# Altium schematic sheet Peripherals Schematic.SchDoc("Peripherals Schematic")
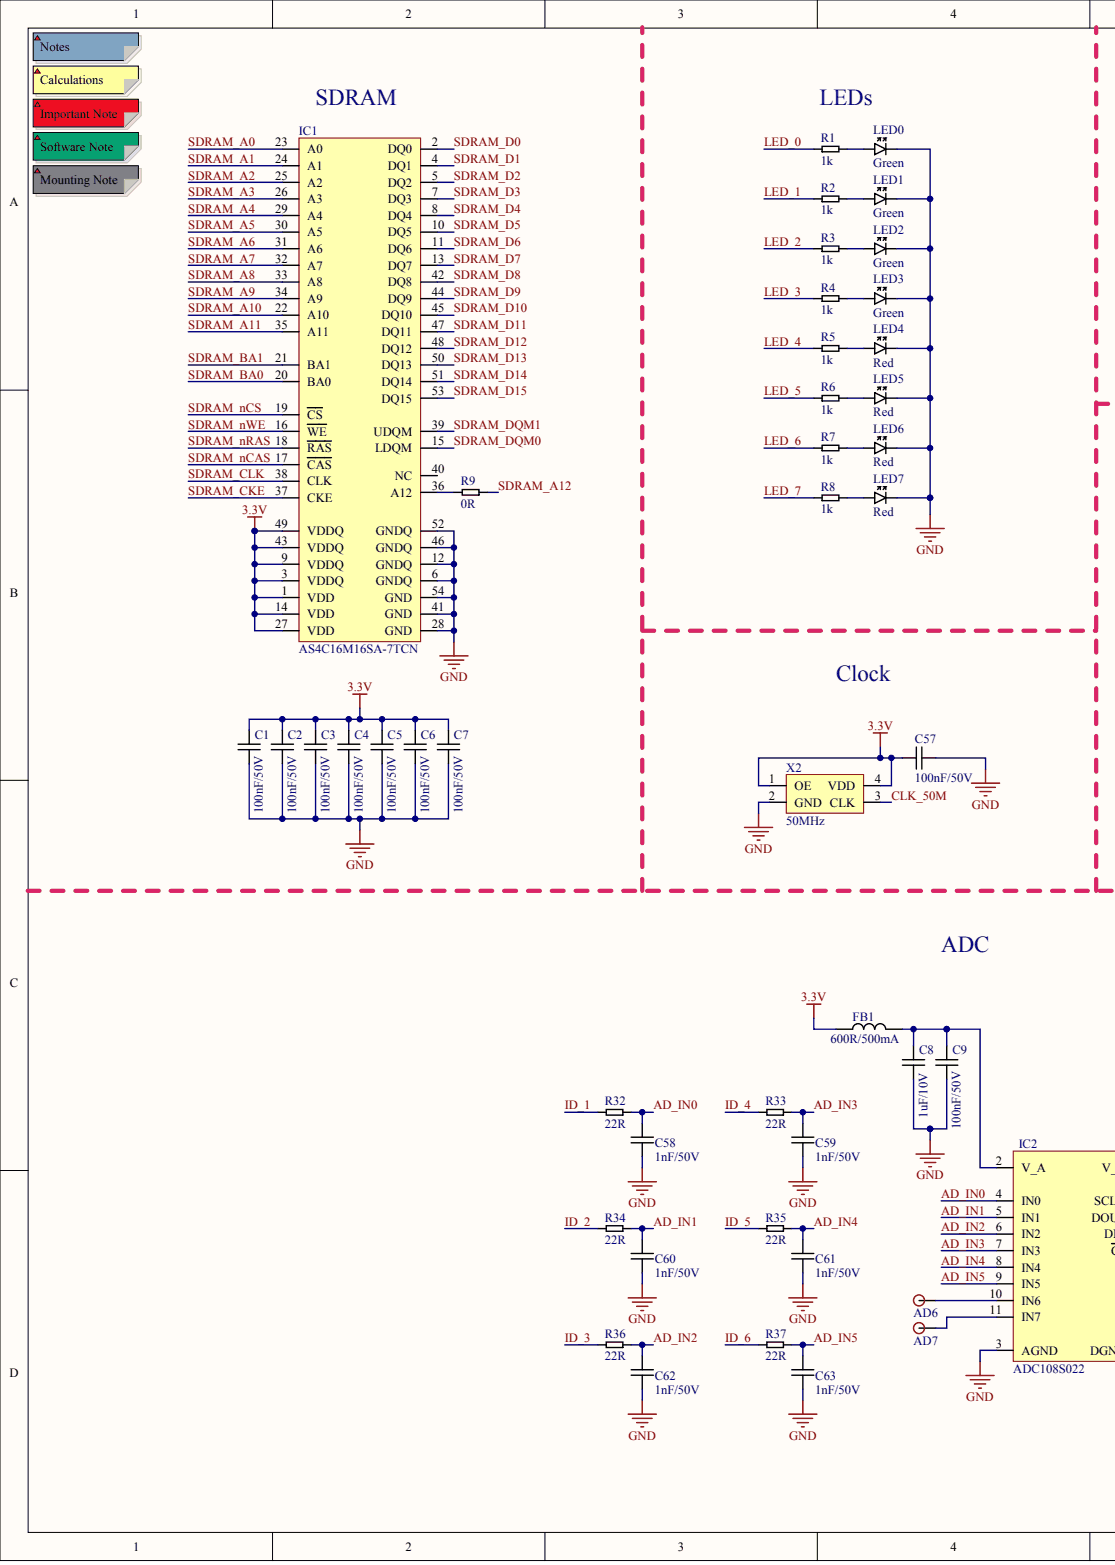
[diagram p0: Peripherals Schematic.SchDoc, left]
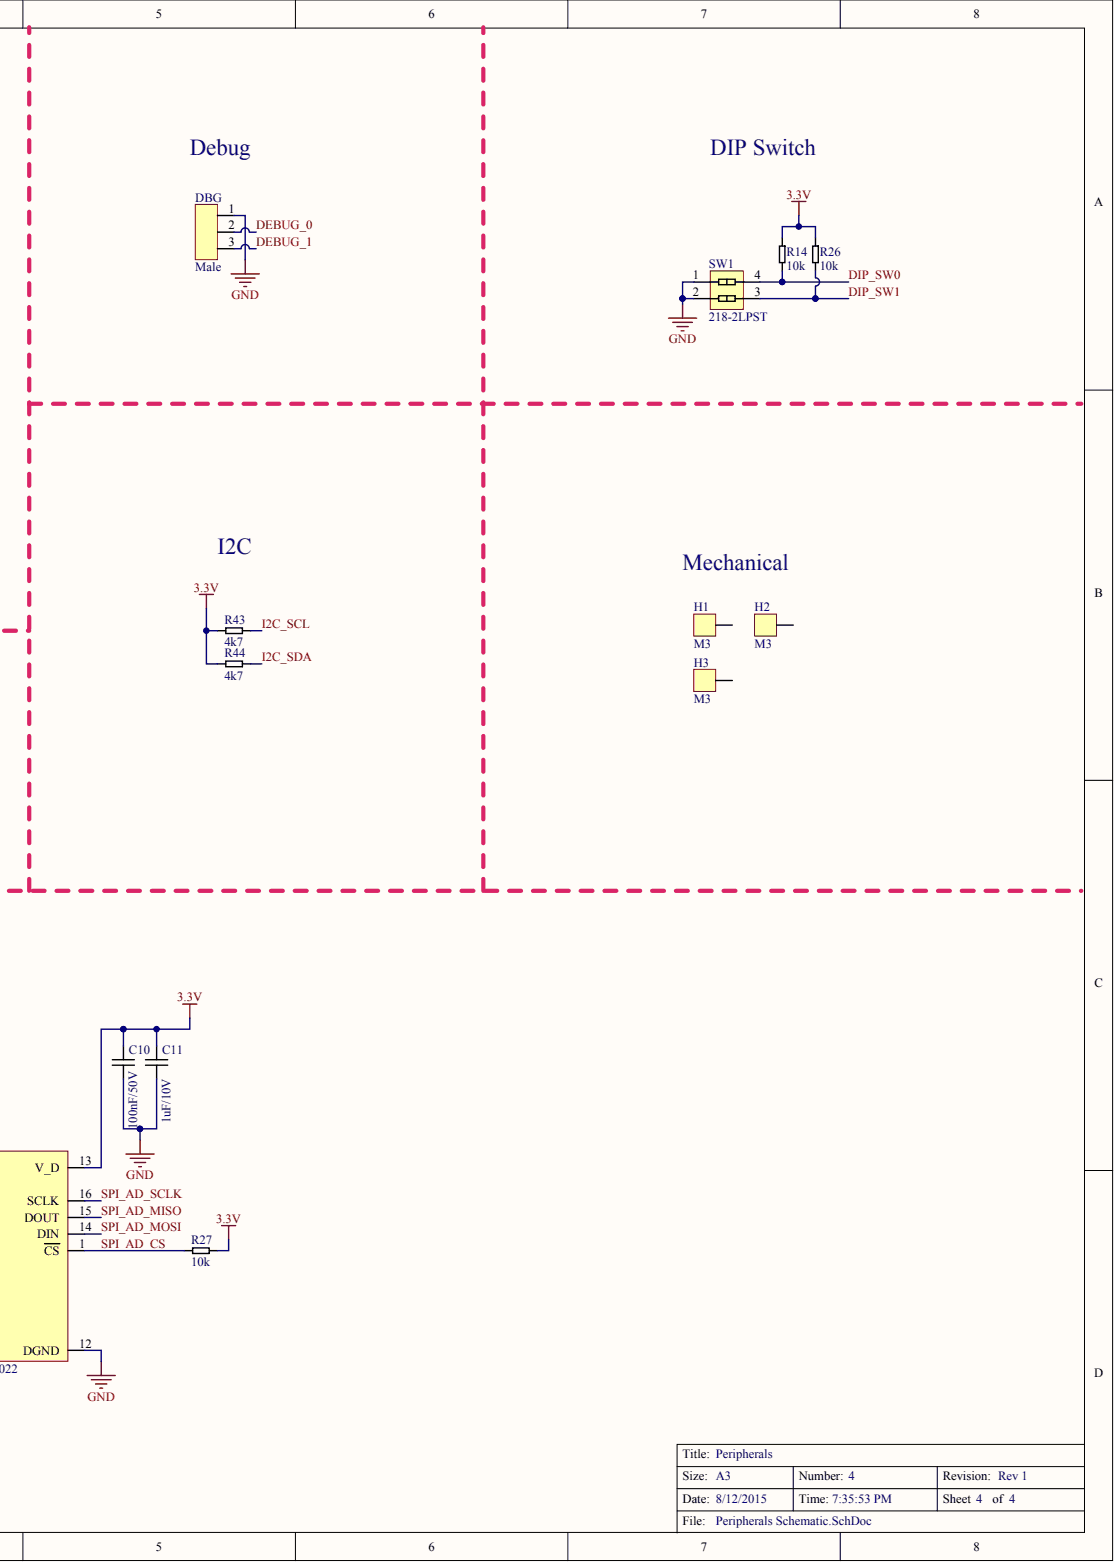
[diagram p1: Peripherals Schematic.SchDoc, right]

## Parts
AD6  Testpad  (value not drawn)
AD7  Testpad  (value not drawn)
C1  value 100nF/50V  [0603]  (hidden comment: Cap_0603_100nF/50V)
C2  value 100nF/50V  [0603]  (hidden comment: Cap_0603_100nF/50V)
C3  value 100nF/50V  [0603]  (hidden comment: Cap_0603_100nF/50V)
C4  value 100nF/50V  [0603]  (hidden comment: Cap_0603_100nF/50V)
C5  value 100nF/50V  [0603]  (hidden comment: Cap_0603_100nF/50V)
C6  value 100nF/50V  [0603]  (hidden comment: Cap_0603_100nF/50V)
C7  value 100nF/50V  [0603]  (hidden comment: Cap_0603_100nF/50V)
C8  value 1uF/10V  [0603]  (hidden comment: Cap_0603_1uF/10V)
C9  value 100nF/50V  [0603]  (hidden comment: Cap_0603_100nF/50V)
C10  value 100nF/50V  [0603]  (hidden comment: Cap_0603_100nF/50V)
C11  value 1uF/10V  [0603]  (hidden comment: Cap_0603_1uF/10V)
C57  value 100nF/50V  [0603]  (hidden comment: Cap_0603_100nF/50V)
C58  value 1nF/50V  [0603]  (hidden comment: Cap_0603_1nF/50V)
C59  value 1nF/50V  [0603]  (hidden comment: Cap_0603_1nF/50V)
C60  value 1nF/50V  [0603]  (hidden comment: Cap_0603_1nF/50V)
C61  value 1nF/50V  [0603]  (hidden comment: Cap_0603_1nF/50V)
C62  value 1nF/50V  [0603]  (hidden comment: Cap_0603_1nF/50V)
C63  value 1nF/50V  [0603]  (hidden comment: Cap_0603_1nF/50V)
DBG  value Male  (hidden comment: Connector 1x3)
FB1  value 600R/500mA  [0603]  (hidden comment: Ferrite_Bead_0603_600R_500mA)
H1  value M3  (hidden comment: M3_SCREWHOLE)
H2  value M3  (hidden comment: M3_SCREWHOLE)
H3  value M3  (hidden comment: M3_SCREWHOLE)
IC1  AS4C16M16SA-7TCN
IC2  ADC108S022
LED0  value Green  (hidden comment: LED_0603_Green)
LED1  value Green  (hidden comment: LED_0603_Green)
LED2  value Green  (hidden comment: LED_0603_Green)
LED3  value Green  (hidden comment: LED_0603_Green)
LED4  value Red  (hidden comment: LED_0603_Red)
LED5  value Red  (hidden comment: LED_0603_Red)
LED6  value Red  (hidden comment: LED_0603_Red)
LED7  value Red  (hidden comment: LED_0603_Red)
R1  value 1k  [0603]  (hidden comment: Res_0603_1k)
R2  value 1k  [0603]  (hidden comment: Res_0603_1k)
R3  value 1k  [0603]  (hidden comment: Res_0603_1k)
R4  value 1k  [0603]  (hidden comment: Res_0603_1k)
R5  value 1k  [0603]  (hidden comment: Res_0603_1k)
R6  value 1k  [0603]  (hidden comment: Res_0603_1k)
R7  value 1k  [0603]  (hidden comment: Res_0603_1k)
R8  value 1k  [0603]  (hidden comment: Res_0603_1k)
R9  value 0R  [0603]  (hidden comment: Res_0603_0R)
R14  value 10k  [0603]  (hidden comment: Res_0603_10k)
R26  value 10k  [0603]  (hidden comment: Res_0603_10k)
R27  value 10k  [0603]  (hidden comment: Res_0603_10k)
R32  value 22R  [0603]  (hidden comment: Res_0603_22R)
R33  value 22R  [0603]  (hidden comment: Res_0603_22R)
R34  value 22R  [0603]  (hidden comment: Res_0603_22R)
R35  value 22R  [0603]  (hidden comment: Res_0603_22R)
R36  value 22R  [0603]  (hidden comment: Res_0603_22R)
R37  value 22R  [0603]  (hidden comment: Res_0603_22R)
R43  value 4k7  [0603]  (hidden comment: Res_0603_4k7)
R44  value 4k7  [0603]  (hidden comment: Res_0603_4k7)
SW1  value 218-2LPST  Part No.: 218-2LPST  (hidden comment: DIP 2-way 218-2LPST)
X2  value 50MHz  [4-pin SMD 2.5x3.2mm]  (hidden comment: 501AAA50M0000CAF)

## Nets
3.3V: C1.2 C2.2 C3.2 C4.2 C5.2 C6.2 C7.2 C10.2 C11.2 C57.1 FB1.1 IC1.1(VDD) IC1.3(VDDQ) IC1.9(VDDQ) IC1.14(VDD) IC1.27(VDD) IC1.43(VDDQ) IC1.49(VDDQ) IC2.13(V_D) R14.2 R26.2 R27.2 R43.1 R44.1 X2.1(OE) X2.4(VDD)
GND: C1.1 C2.1 C3.1 C4.1 C5.1 C6.1 C7.1 C8.1 C9.1 C10.1 C11.1 C57.2 C58.1 C59.1 C60.1 C61.1 C62.1 C63.1 DBG.1 IC1.6(GNDQ) IC1.12(GNDQ) IC1.28(GND) IC1.41(GND) IC1.46(GNDQ) IC1.52(GNDQ) IC1.54(GND) IC2.3(AGND) IC2.12(DGND) LED0.CATHODE LED1.CATHODE LED2.CATHODE LED3.CATHODE LED4.CATHODE LED5.CATHODE LED6.CATHODE LED7.CATHODE SW1.1 SW1.2 X2.2(GND)
AD_IN0: C58.2 IC2.4(IN0) R32.2
AD_IN1: C60.2 IC2.5(IN1) R34.2
AD_IN2: C62.2 IC2.6(IN2) R36.2
AD_IN3: C59.2 IC2.7(IN3) R33.2
AD_IN4: C61.2 IC2.8(IN4) R35.2
AD_IN5: C63.2 IC2.9(IN5) R37.2
CLK_50M: X2.3(CLK)
DEBUG_0: DBG.2
DEBUG_1: DBG.3
DIP_SW0: R14.1 SW1.4
DIP_SW1: R26.1 SW1.3
I2C_SCL: R43.2
I2C_SDA: R44.2
ID_1: R32.1
ID_2: R34.1
ID_3: R36.1
ID_4: R33.1
ID_5: R35.1
ID_6: R37.1
LED_0: R1.2
LED_1: R2.2
LED_2: R3.2
LED_3: R4.2
LED_4: R5.2
LED_5: R6.2
LED_6: R7.2
LED_7: R8.2
SDRAM_A0: IC1.23(A0)
SDRAM_A1: IC1.24(A1)
SDRAM_A2: IC1.25(A2)
SDRAM_A3: IC1.26(A3)
SDRAM_A4: IC1.29(A4)
SDRAM_A5: IC1.30(A5)
SDRAM_A6: IC1.31(A6)
SDRAM_A7: IC1.32(A7)
SDRAM_A8: IC1.33(A8)
SDRAM_A9: IC1.34(A9)
SDRAM_A10: IC1.22(A10)
SDRAM_A11: IC1.35(A11)
SDRAM_A12: R9.2
SDRAM_BA0: IC1.20(BA0)
SDRAM_BA1: IC1.21(BA1)
SDRAM_CKE: IC1.37(CKE)
SDRAM_CLK: IC1.38(CLK)
SDRAM_D0: IC1.2(DQ0)
SDRAM_D1: IC1.4(DQ1)
SDRAM_D2: IC1.5(DQ2)
SDRAM_D3: IC1.7(DQ3)
SDRAM_D4: IC1.8(DQ4)
SDRAM_D5: IC1.10(DQ5)
SDRAM_D6: IC1.11(DQ6)
SDRAM_D7: IC1.13(DQ7)
SDRAM_D8: IC1.42(DQ8)
SDRAM_D9: IC1.44(DQ9)
SDRAM_D10: IC1.45(DQ10)
SDRAM_D11: IC1.47(DQ11)
SDRAM_D12: IC1.48(DQ12)
SDRAM_D13: IC1.50(DQ13)
SDRAM_D14: IC1.51(DQ14)
SDRAM_D15: IC1.53(DQ15)
SDRAM_DQM0: IC1.15(LDQM)
SDRAM_DQM1: IC1.39(UDQM)
SDRAM_NCAS: IC1.17(CAS)
SDRAM_NCS: IC1.19(CS)
SDRAM_NRAS: IC1.18(RAS)
SDRAM_NWE: IC1.16(WE)
SPI_AD_CS: IC2.1(CS) R27.1
SPI_AD_MISO: IC2.15(DOUT)
SPI_AD_MOSI: IC2.14(DIN)
SPI_AD_SCLK: IC2.16(SCLK)
NetAD6_1: AD6.1 IC2.10(IN6)
NetAD7_1: AD7.1 IC2.11(IN7)
NetC8_2: C8.2 C9.2 FB1.2 IC2.2(V_A)
NetIC1_36: IC1.36(A12) R9.1
NetLED0_ANODE: LED0.ANODE R1.1
NetLED1_ANODE: LED1.ANODE R2.1
NetLED2_ANODE: LED2.ANODE R3.1
NetLED3_ANODE: LED3.ANODE R4.1
NetLED4_ANODE: LED4.ANODE R5.1
NetLED5_ANODE: LED5.ANODE R6.1
NetLED6_ANODE: LED6.ANODE R7.1
NetLED7_ANODE: LED7.ANODE R8.1

Not connected: H1 HOLE; H2 HOLE; H3 HOLE; IC1 40(NC)

## Library data of the parts
C1-C7 C9 C10 C57 = (no symbol name): Part No.: C1608X7R1H104K080AA; Manufacturer: TDK; RS: 741-4459 (500-pack); DigiKey: 445-1314-1-ND; Mouser: 810-C1608X7R1H104K; Price SEK (No VAT): 0,2
C8 C11 = (no symbol name): Part No.: C1608X5R1A105K080AC; Manufacturer: TDK; Mouser: 810-C1608X5R1A105K; Price SEK (No VAT): 1; DigiKey: 445-1321-1-ND
C58-C63 = (no symbol name): Part No.: C1608X7R1H102K080AE; Manufacturer: TDK; Mouser: 810-C1608X7R1H102KAE; DigiKey: 445-15923-1-ND; Price SEK (No VAT): 0,4
FB1 = (no symbol name): Part No.: MMZ1608B601C; Manufacturer: TDK; RS: 786-5015; Price SEK (No VAT): 1; Mouser: 810-MMZ1608B601C; DigiKey: 445-2166-1-ND; Farnell: 1669687
IC1 = (no symbol name): Manufacturer: Alliance Memory; DigiKey: 1450-1255-ND; Part No.: AS4C16M16SA-7TCN; Price SEK (No VAT): 25; Datasheet: http://www.alliancememory.com/pdf/dram/256Mb-AS4C16M16SA-C&I_V3.0_March%202015.pdf; Mouser: 913-4C16M16SA-7TCN; Value: AS4C16M16SA-7TCN
IC2 = (no symbol name): Part No.: ADC108S022CIMT; Manufacturer: TI; 639-4165: 639-4165 (5-pack); DigiKey: 296-41180-1-ND; Price SEK (No VAT): 40; Datasheet: http://www.ti.com/lit/ds/symlink/adc108s022.pdf; Mouser: 926-A108S022CIMTXNPB; Value: ADC108S022
LED0-LED3 = (no symbol name): Part No.: APT1608CGCK; Manufacturer: Kingbright; Mouser: 604-APT1608CGCK; Price SEK (No VAT): 1; DigiKey: 754-1116-1-ND
LED4-LED7 = (no symbol name): Part No.: APT1608SRCPRV; Manufacturer: Kingbright; RS: 654-5420; DigiKey: 754-1122-1-ND; Price SEK (No VAT): 1; Mouser: 604-APT1608SRCPRV
R1-R8 = (no symbol name): Part No.: CRCW06031K00FKEA; Manufacturer: Vishay; Price SEK (No VAT): 0,1; DigiKey: 541-1.00KHCT-ND; Mouser: 71-CRCW0603-1.0K-E3
R9 = (no symbol name): Part No.: CRCW06030000Z0EA; Manufacturer: Vishay; Price SEK (No VAT): 0,1; DigiKey: 541-0.0GCT-ND; Mouser: 71-CRCW0603-0-E3
R14 R26 R27 R43 R44 = (no symbol name): Part No.: CRCW060310K0FKEA; Manufacturer: Vishay; Price SEK (No VAT): 0,1; Mouser: 71-CRCW0603-10K-E3; DigiKey: 541-10.0KHCT-ND
R32-R37 = (no symbol name): Part No.: CRCW060322R0FKEA; Manufacturer: Vishay; Price SEK (No VAT): 0,1; Mouser: 71-CRCW0603-22-E3; DigiKey: 541-22.0HCT-ND
SW1 = (no symbol name): Manufacturer: CTS Electronic Components; Mouser: 774-2182LPST; DigiKey: CT2182LPST-ND; Price SEK (No VAT): 10; Datasheet: http://www.ctscorp.com/components/Datasheets/218.pdf
X2 = (no symbol name): Manufacturer: Silicon Labs; Part No.: 501AAA50M0000CAF; Mouser: 634-501AAA50M0000CAF; DigiKey: 336-2978-ND; Price SEK (No VAT): 10
